# Altium schematic sheet Connectors.SchDoc
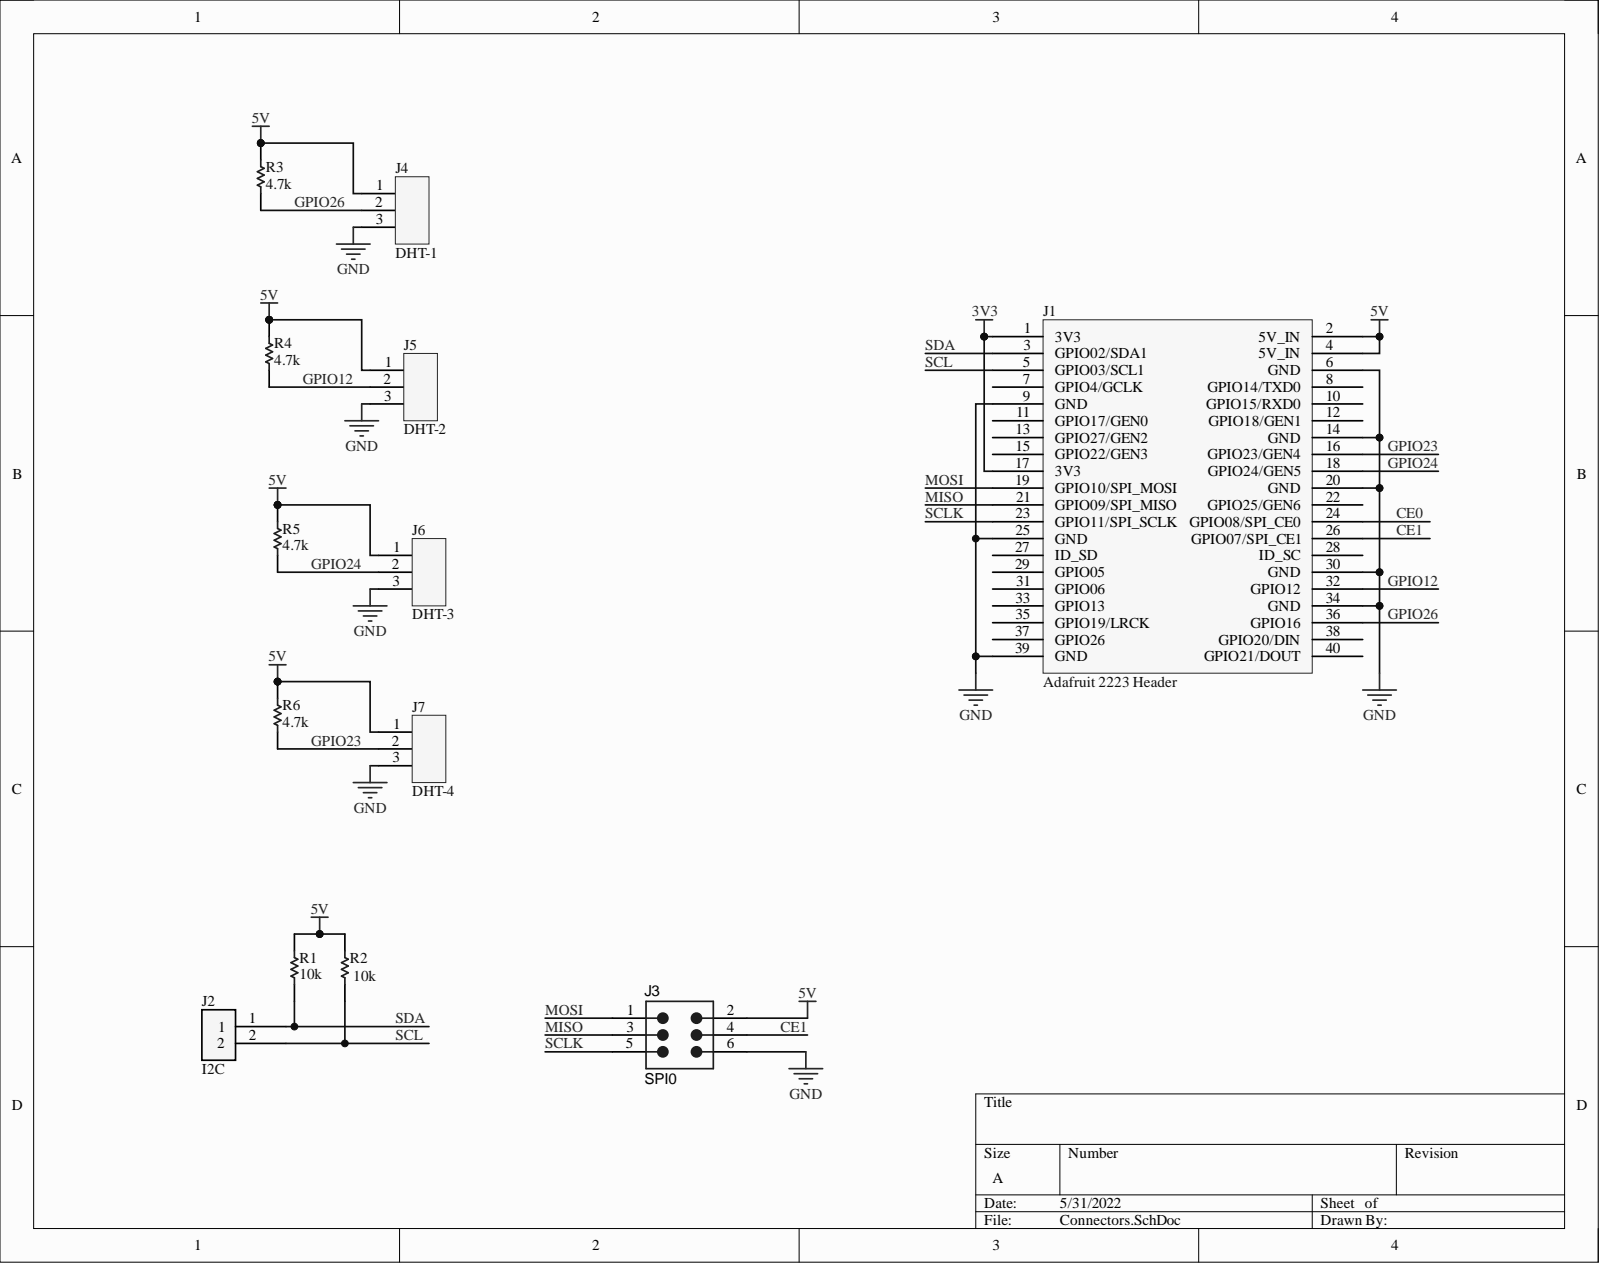
[diagram p0: Connectors.SchDoc]

## Parts
J1  Adafruit 2223 Header  [PCB-dv9vmhrfa2chsb9gidwm-1]
J2  I2C  [PCB-t2j72m1yraok0w090vy8-1]
J3  SPI0  [PCB-195qa83xwzmdfln5hnix-1]
J4  DHT-1  [PCB-s0hfil65plaomi4ibvcw-1]
J5  DHT-2  [PCB-s0hfil65plaomi4ibvcw-1]
J6  DHT-3  [PCB-s0hfil65plaomi4ibvcw-1]
J7  DHT-4  [PCB-s0hfil65plaomi4ibvcw-1]
R1  10k  [PCB-urbe6pl2f36u5sj9z9e0-1]
R2  10k  [PCB-urbe6pl2f36u5sj9z9e0-1]
R3  4.7k  [PCB-etsube69rmkcafwxmreb-1]
R4  4.7k  [PCB-etsube69rmkcafwxmreb-1]
R5  4.7k  [PCB-etsube69rmkcafwxmreb-1]
R6  4.7k  [PCB-etsube69rmkcafwxmreb-1]

## Nets
3V3: J1.1(3V3) J1.17(3V3)
5V: J1.2(5V_IN) J1.4(5V_IN) J3.2 J4.1 J5.1 J6.1 J7.1 R1.2 R2.2 R3.2 R4.2 R5.2 R6.2
GND: J1.6(GND) J1.9(GND) J1.14(GND) J1.20(GND) J1.25(GND) J1.30(GND) J1.34(GND) J1.39(GND) J3.6 J4.3 J5.3 J6.3 J7.3
CE0: J1.24(GPIO08/SPI_CE0)
CE1: J1.26(GPIO07/SPI_CE1) J3.4
GPIO12: J1.32(GPIO12) J5.2 R4.1
GPIO23: J1.16(GPIO23/GEN4) J7.2 R6.1
GPIO24: J1.18(GPIO24/GEN5) J6.2 R5.1
GPIO26: J1.36(GPIO16) J4.2 R3.1
MISO: J1.21(GPIO09/SPI_MISO) J3.3
MOSI: J1.19(GPIO10/SPI_MOSI) J3.1
SCL: J1.5(GPIO03/SCL1) J2.2 R2.1
SCLK: J1.23(GPIO11/SPI_SCLK) J3.5
SDA: J1.3(GPIO02/SDA1) J2.1 R1.1

Not connected: J1 7(GPIO4/GCLK) 8(GPIO14/TXD0) 10(GPIO15/RXD0) 11(GPIO17/GEN0) 12(GPIO18/GEN1) 13(GPIO27/GEN2) 15(GPIO22/GEN3) 22(GPIO25/GEN6) 27(ID_SD) 28(ID_SC) 29(GPIO05) 31(GPIO06) 33(GPIO13) 35(GPIO19/LRCK) 37(GPIO26) 38(GPIO20/DIN) 40(GPIO21/DOUT)

## Library data of the parts
J1 = CMP-4e918466a73d9156-23: GPIO Stacking Header for Pi A+/B+/Pi 2/Pi 3 - Extra-long 2x20 Pins; Component Kind: Standard; Library Name: CommunityVault
J2 = CMP-7939b1c60980a6fe-3: Board-To-Board Connector, Right Angle, WR-PHD Series, Through Hole, Header, 2, 2.54 mm; Manufacturer Part Number: 61300211021; Gender: Male; Number of Contacts: 2; Component Kind: Standard; Contact Material: Copper; Contact Plating: Gold; Library Name: CommunityVault; Mounting Style: Through Hole; Octopart URL: http://octopart.com/61300211021-w%C3%BCrth+elektronik-32855519; OctopartID: 7939b1c60980a6fe; REACH SVHC Compliance: No SVHC; RoHS: Compliant
J3 = CMP-3ebff6404e5de178-2: Board-To-Board Connector, WR-PHD Series, Surface Mount, Header, 6, 2.54 mm, Gold Plated Contacts; Manufacturer Part Number: 61000621121; Gender: Male; Number of Contacts: 6; Component Kind: Standard; Contact Material: Copper; Contact Plating: Gold; Library Name: CommunityVault; Mounting Style: Surface Mount, Vertical; Octopart URL: http://octopart.com/61000621121-w%C3%BCrth+elektronik-32855428; OctopartID: 3ebff6404e5de178; REACH SVHC Compliance: No SVHC; RoHS: Compliant
J4-J7 = CMP-8a90426ffa175557-32: THT Vertical Pin Header WR-PHD, Pitch 2.54 mm, Single Row, 3 pins; Manufacturer Part Number: 61300311121; Current Rating: 3.00 A; Dielectric Withstanding Voltage: 500 V; Gender: Male; Number of Contacts: 3; Component Kind: Standard; Contact Material: Copper; Library Name: CommunityVault; Mounting Style: Through Hole; Number of Pins: 3; OctopartID: 8a90426ffa175557; Operating Temperature: -40.0 °C (min); Packaging: Bag; REACH SVHC Compliance: No SVHC; RoHS: Compliant
R1 R2 = CMP-1974d512ef63c6b3-1: Res, AEC-Q200, Thick Film, 10K, 5%, 0603; Manufacturer Part Number: CRGCQ0603J10K; Component Kind: Standard; Library Name: CommunityVault; Lifecycle Status: Not Listed by Manufacturer; Octopart URL: https://octopart.com/crgcq0603j10k-te+connectivity-91018097; OctopartID: 1974d512ef63c6b3; Packaging: Cut Tape (CT); Resistance Tolerance: ±5%
R3-R6 = CMP-e8892324e857374e-1: Res Thin Film 0603 4.7K Ohm 1% 1/10W ±50ppm/°C Molded SMD SMD Paper T/R; Manufacturer Part Number: MCT06030C4701FP500; Case/Package (SI): 1608; Lead-Free Status: Lead Free; Power Rating: 125 mW; Resistance: 4.70 kΩ; Voltage Rating (DC): 75.0 V; Case/Package: 1608, 0603; Component Kind: Standard; Library Name: CommunityVault; Mounting Style: Surface Mount; OctopartID: e8892324e857374e; Packaging: Cut Tape (CT); Resistance Tolerance: ±1%; RoHS: Compliant; Size-Height: 450 µm; Size-Length: 1.55 mm; Size-Width: 850 µm
